# Altium schematic sheet ArduinoWiring.SchDoc
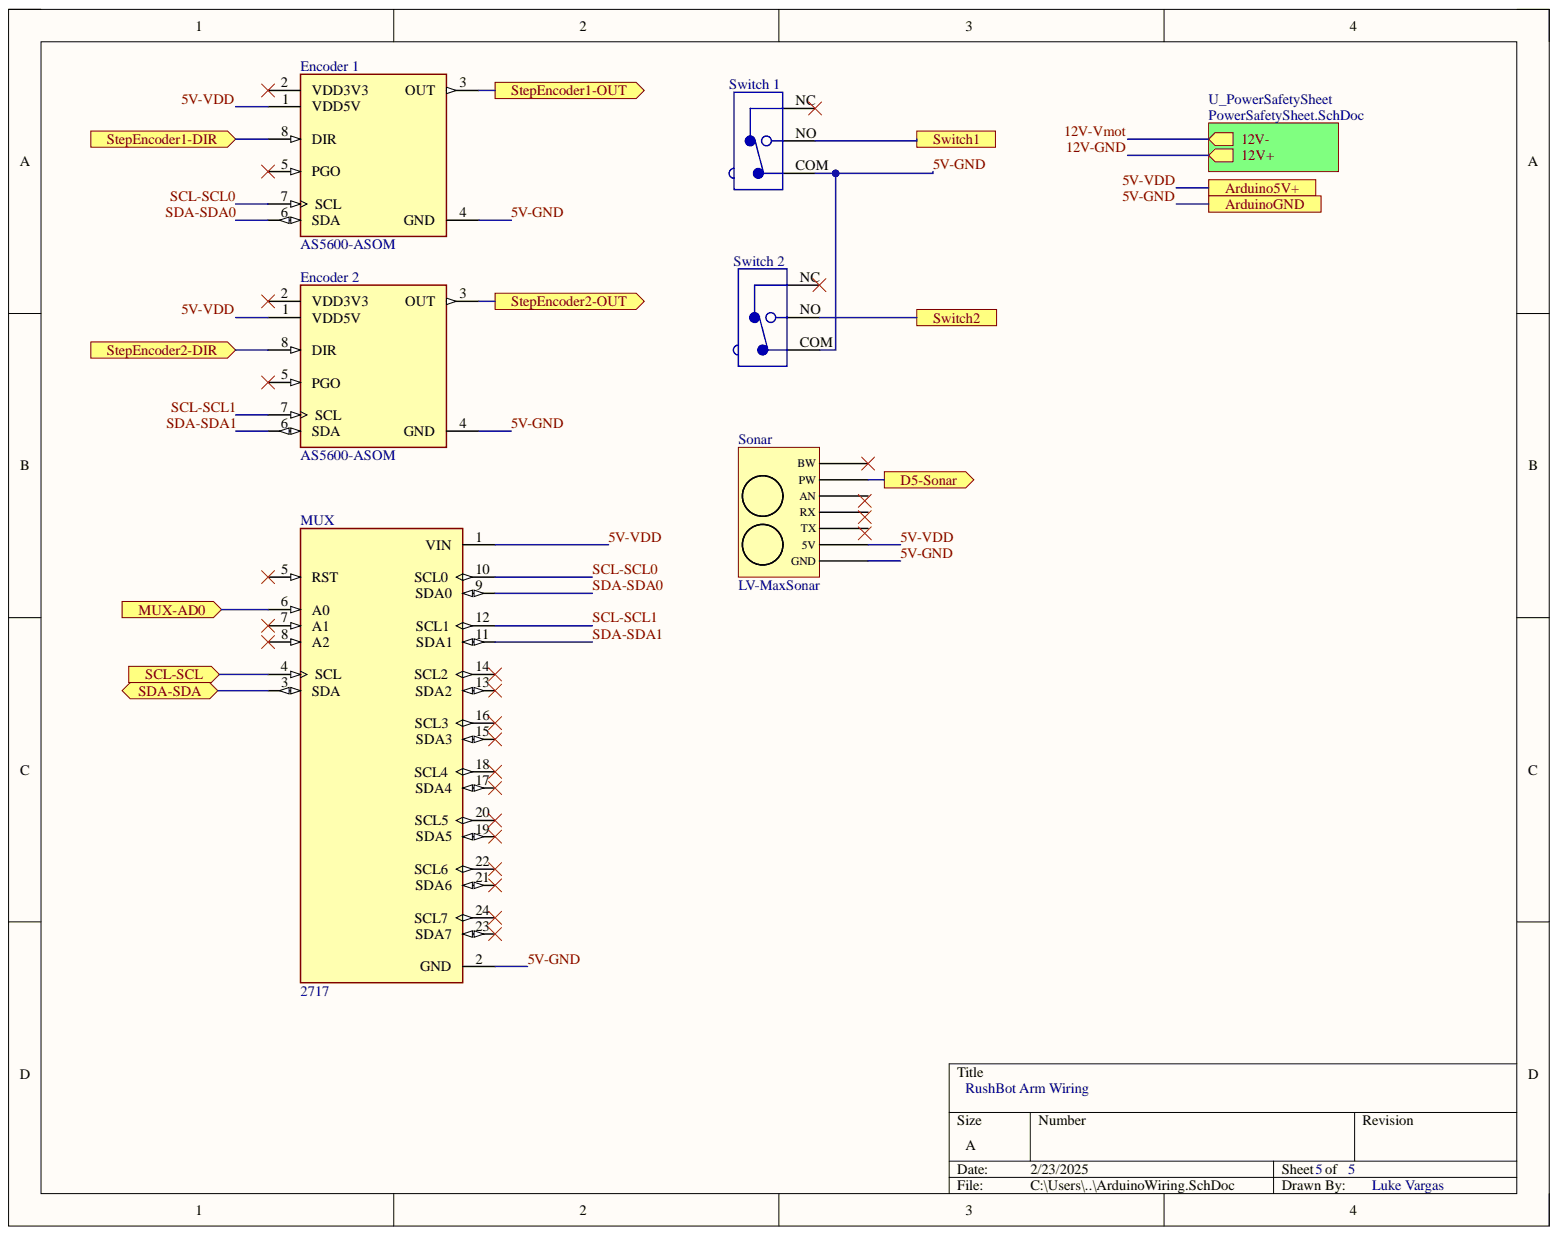
[diagram p0: ArduinoWiring.SchDoc]

## Parts
AS5600-ASOM  Encoder 2  [FP-AS5600-ASOM-IPC_C]
AS5600-ASOM  Encoder 1  [FP-AS5600-ASOM-IPC_C]
MUX  2717  [MODULE_2717]
Sonar  LV-MaxSonar
Switch_1  463092370402  [463092370402]  (value not drawn)
Switch_2  463092370402  [463092370402]  (value not drawn)

## Ports
Arduino5V+, ArduinoGND, D5-Sonar, MUX-AD0, SCL-SCL, SDA-SDA, StepEncoder1-DIR, StepEncoder1-OUT, StepEncoder2-DIR, StepEncoder2-OUT, Switch1, Switch2

## Nets
5V-GND: AS5600-ASOM.4 MUX.2(GND) Sonar.1(GND) Switch_1.COM Switch_2.COM ; port ArduinoGND
5V-VDD: AS5600-ASOM.1 MUX.1(VIN) Sonar.2(5V) ; port Arduino5V+
D5-Sonar: Sonar.6(PW) ; port D5-Sonar
MUX-AD0: MUX.6(A0) ; port MUX-AD0
SCL-SCL: MUX.4(SCL) ; port SCL-SCL
SCL-SCL0: AS5600-ASOM.7 MUX.10(SCL0)
SCL-SCL1: AS5600-ASOM.7 MUX.12(SCL1)
SDA-SDA: MUX.3(SDA) ; port SDA-SDA
SDA-SDA0: AS5600-ASOM.6 MUX.9(SDA0)
SDA-SDA1: AS5600-ASOM.6 MUX.11(SDA1)
StepEncoder1-DIR: AS5600-ASOM.8 ; port StepEncoder1-DIR, port StepEncoder2-DIR
StepEncoder1-OUT: AS5600-ASOM.3 ; port StepEncoder1-OUT, port StepEncoder2-OUT
Switch1: Switch_1.NO ; port Switch1
Switch2: Switch_2.NO ; port Switch2
12V-GND:
12V-Vmot:

Not connected: AS5600-ASOM 2 5; MUX 5(RST) 7(A1) 8(A2) 13(SDA2) 14(SCL2) 15(SDA3) 16(SCL3) 17(SDA4) 18(SCL4) 19(SDA5) 20(SCL5) 21(SDA6) 22(SCL6) 23(SDA7) 24(SCL7); Sonar 3(TX) 4(RX) 5(AN) 7(BW); Switch_1 NC; Switch_2 NC

## Library data of the parts
AS5600-ASOM AS5600-ASOM = CMP-22826-000002-1: SENSOR ANGLE 360DEG SMD; Sensing Method: Hall Effect; Component Kind: Standard; Lead Free: Lead Free; Library Name: Altium Content Vault; Max Operating Temperature: 125°C; Min Operating Temperature: -40°C; Mount: Surface Mount; Termination: SMD/SMT
MUX = CMP-015-00008-1: Module: multiplexer; I2C interface multiplexer; TCA9548A; 3Ã·5VDC; MF: Adafruit; Availability: In Stock; Case/Package: None; Check_prices: https://www.snapeda.com/parts/2717/Adafruit+Industries+LLC/view-part/?ref=eda; Component Kind: Standard; Component Type: Standard; Design Item ID: 2717; MP: 2717; Pins: 24; Price: None; Purchase-URL: https://pricing.snapeda.com/search/part/2717/?ref=eda; SnapEDA_Link: https://www.snapeda.com/parts/2717/Adafruit+Industries+LLC/view-part/?ref=snap; Source: 2717.SchLib; Library Name: Thousand Sunny
Sonar = Sonar-001-1: LV-MaxSonar-EZ1 High Performance Ultrasonic Rangefinder 0-21 Feet; Component Kind: Standard; Library Name: Thousand Sunny
Switch_1 Switch_2 = CMP-011-00015-1: WS-MITV THT Micro Switch with Lever, SPDT, 30VDC, 2A, 80g; Manufacturer: Wurth Elektronik; Category: Micro Switches; Circuit: SPDT; Component Kind: Standard; Component Type: Standard; ComponentLink1Description: Website Link; ComponentLink1URL: https://www.we-online.com/redexpert/article/463092370402?ad; ComponentLink2Description: Datasheet Link; ComponentLink2URL: https://www.we-online.com/redexpert/spec/463092370402?ad; Contact Material: Copper Alloy; Contact Plating: Silver; Design Item ID: 463092370402; Manufacturer Part Number: 463092370402; Match Code: WS-MITV; Mount: Through Hole; Operating Temperature Max: 85°C; Operating Temperature Min: -40°C; Operation Force: 80g; Packaging: Tray; Pins: 3; Rated Current: 2A; Rated Voltage: 30VDC; Source: WS-MITV.SchLib; Terminal Material: Copper Alloy; Terminal Plating: Silver; Library Name: Thousand Sunny
